# Altium schematic sheet Serial Layer v1.2.SchDoc
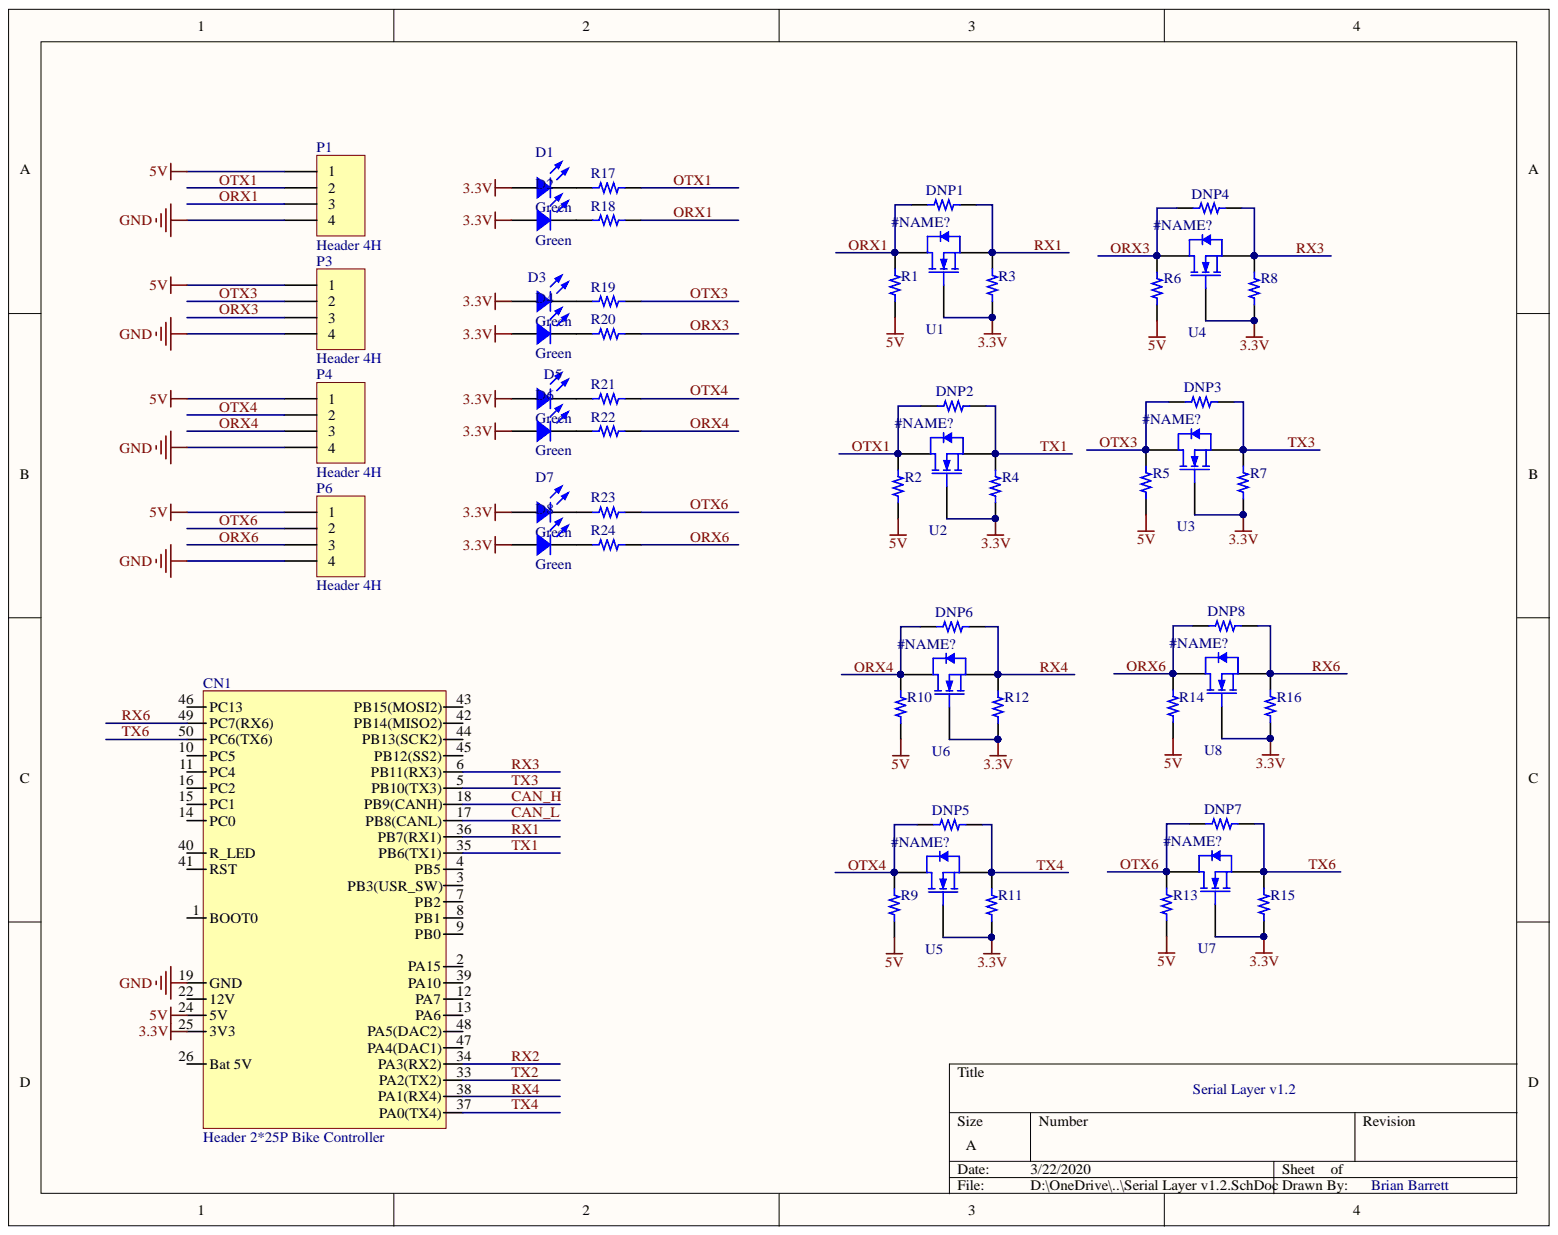
[diagram p0: Serial Layer v1.2.SchDoc]

## Parts
CN1  Header 2*25P Bike Controller  [1.0mm 2X25 Header - Dbl Layer]
D1  Green  [LED 0603]
D2  Green  [LED 0603]
D3  Green  [LED 0603]
D4  Green  [LED 0603]
D5  Green  [LED 0603]
D6  Green  [LED 0603]
D7  Green  [LED 0603]
D8  Green  [LED 0603]
DNP1  (no value)  [RES 0603/1608 RF]
DNP2  (no value)  [RES 0603/1608 RF]
DNP3  (no value)  [RES 0603/1608 RF]
DNP4  (no value)  [RES 0603/1608 RF]
DNP5  (no value)  [RES 0603/1608 RF]
DNP6  (no value)  [RES 0603/1608 RF]
DNP7  (no value)  [RES 0603/1608 RF]
DNP8  (no value)  [RES 0603/1608 RF]
P1  Header 4H  [SH 1.0 * 4]
P3  Header 4H  [SH 1.0 * 4]
P4  Header 4H  [SH 1.0 * 4]
P6  Header 4H  [SH 1.0 * 4]
R1  (no value)  [RES 0603/1608 RF]
R2  (no value)  [RES 0603/1608 RF]
R3  (no value)  [RES 0603/1608 RF]
R4  (no value)  [RES 0603/1608 RF]
R5  (no value)  [RES 0603/1608 RF]
R6  (no value)  [RES 0603/1608 RF]
R7  (no value)  [RES 0603/1608 RF]
R8  (no value)  [RES 0603/1608 RF]
R9  (no value)  [RES 0603/1608 RF]
R10  (no value)  [RES 0603/1608 RF]
R11  (no value)  [RES 0603/1608 RF]
R12  (no value)  [RES 0603/1608 RF]
R13  (no value)  [RES 0603/1608 RF]
R14  (no value)  [RES 0603/1608 RF]
R15  (no value)  [RES 0603/1608 RF]
R16  (no value)  [RES 0603/1608 RF]
R17  (no value)  [RES 0603/1608 RF]
R18  (no value)  [RES 0603/1608 RF]
R19  (no value)  [RES 0603/1608 RF]
R20  (no value)  [RES 0603/1608 RF]
R21  (no value)  [RES 0603/1608 RF]
R22  (no value)  [RES 0603/1608 RF]
R23  (no value)  [RES 0603/1608 RF]
R24  (no value)  [RES 0603/1608 RF]
U1  #NAME?  [SOT-23]
U2  #NAME?  [SOT-23]
U3  #NAME?  [SOT-23]
U4  #NAME?  [SOT-23]
U5  #NAME?  [SOT-23]
U6  #NAME?  [SOT-23]
U7  #NAME?  [SOT-23]
U8  #NAME?  [SOT-23]

## Nets
3.3V: CN1.25(3V3) D1.1 D2.1 D3.1 D4.1 D5.1 D6.1 D7.1 D8.1 R3.2 R4.2 R7.2 R8.2 R11.2 R12.2 R15.2 R16.2 U1.1 U2.1 U3.1 U4.1 U5.1 U6.1 U7.1 U8.1
5V: CN1.24(5V) P1.1 P3.1 P4.1 P6.1 R1.2 R2.2 R5.2 R6.2 R9.2 R10.2 R13.2 R14.2
GND: CN1.19(GND) P1.4 P3.4 P4.4 P6.4
CAN_H: CN1.18(PB9(CANH))
CAN_L: CN1.17(PB8(CANL))
ORX1: DNP1.1 P1.3 R1.1 R18.2 U1.4
ORX3: DNP4.1 P3.3 R6.1 R20.2 U4.4
ORX4: DNP6.1 P4.3 R10.1 R22.2 U6.4
ORX6: DNP8.1 P6.3 R14.1 R24.2 U8.4
OTX1: DNP2.1 P1.2 R2.1 R17.2 U2.4
OTX3: DNP3.1 P3.2 R5.1 R19.2 U3.4
OTX4: DNP5.1 P4.2 R9.1 R21.2 U5.4
OTX6: DNP7.1 P6.2 R13.1 R23.2 U7.4
RX1: CN1.36(PB7(RX1)) DNP1.2 R3.1 U1.3
RX2: CN1.34(PA3(RX2))
RX3: CN1.6(PB11(RX3)) DNP4.2 R8.1 U4.3
RX4: CN1.38(PA1(RX4)) DNP6.2 R12.1 U6.3
RX6: CN1.49(PC7(RX6)) DNP8.2 R16.1 U8.3
TX1: CN1.35(PB6(TX1)) DNP2.2 R4.1 U2.3
TX2: CN1.33(PA2(TX2))
TX3: CN1.5(PB10(TX3)) DNP3.2 R7.1 U3.3
TX4: CN1.37(PA0(TX4)) DNP5.2 R11.1 U5.3
TX6: CN1.50(PC6(TX6)) DNP7.2 R15.1 U7.3
NetD1_2: D1.2 R17.1
NetD2_2: D2.2 R18.1
NetD3_2: D3.2 R19.1
NetD4_2: D4.2 R20.1
NetD5_2: D5.2 R21.1
NetD6_2: D6.2 R22.1
NetD7_2: D7.2 R23.1
NetD8_2: D8.2 R24.1

Not connected: CN1 1(BOOT0) 2(PA15) 3(PB3(USR_SW)) 4(PB5) 7(PB2) 8(PB1) 9(PB0) 10(PC5) 11(PC4) 12(PA7) 13(PA6) 14(PC0) 15(PC1) 16(PC2) 22(12V) 26(Bat_5V) 39(PA10) 40(R_LED) 41(RST) 42(PB14(MISO2)) 43(PB15(MOSI2)) 44(PB13(SCK2)) 45(PB12(SS2)) 46(PC13) 47(PA4(DAC1)) 48(PA5(DAC2))

## Library data of the parts
CN1 = Header 2*25P Bike Controller: Header, 5-Pin, Right Angle; Component Kind: Standard; LatestRevisionDate: 17-Jul-2002; LatestRevisionNote: Re-released for DXP Platform.; Library Name: BMI.SchLib; Publisher: Altium Limited
D1-D8 = LED: Chip LED; Component Kind: Standard; Library Name: BMI.SchLib; Value: Green
DNP1-DNP8 R1-R24 = Resistor: SMT Resistor; Component Kind: Standard; Library Name: Passives.SchLib
P1 P3 P4 P6 = Header 4H: Header, 4-Pin, Right Angle; Component Kind: Standard; LatestRevisionDate: 17-Jul-2002; LatestRevisionNote: Re-released for DXP Platform.; Library Name: BMI.SchLib; Signal Integrity: Connector; Publisher: Altium Limited
U1-U8 = N MOSFET: N-Channel MOSFET; Component Kind: Standard; Library Name: BMI.SchLib
